# Altium schematic sheet Sheet1.SchDoc(Sheet1)
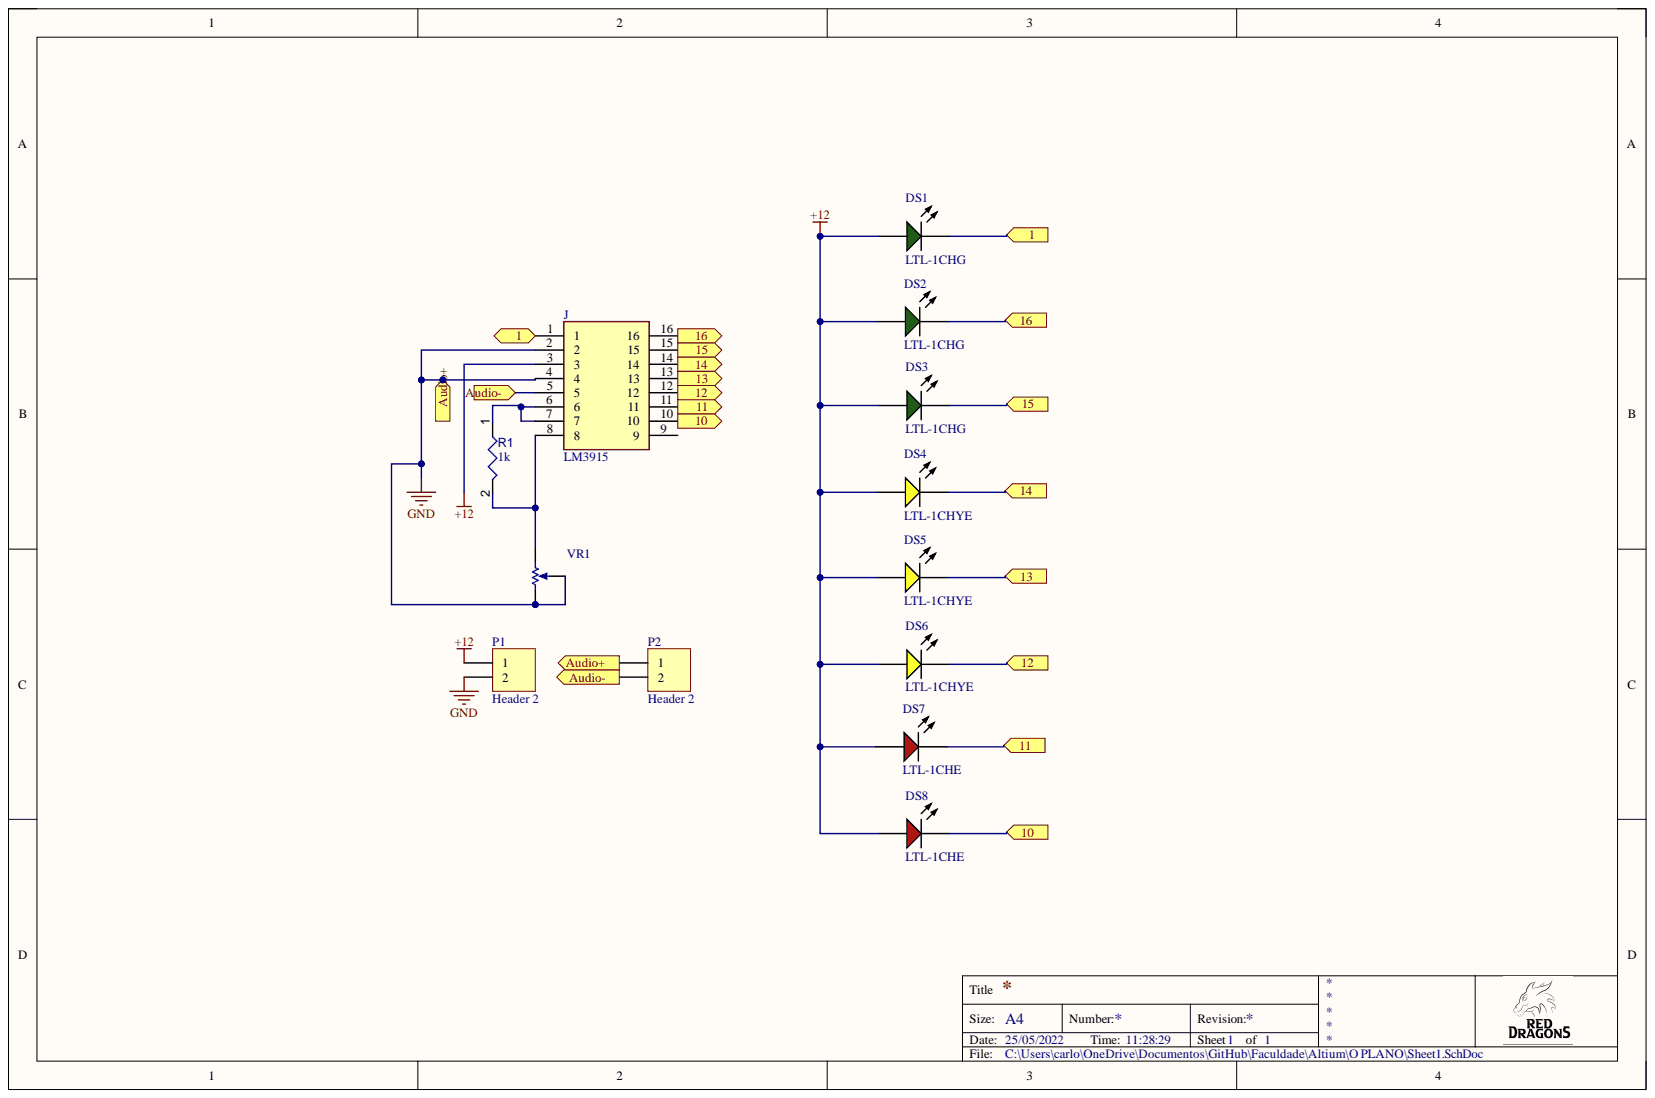
[diagram p0: Sheet1.SchDoc]

## Parts
DS1  LTL-1CHG  [LTST-LTL-1CHG-2]
DS2  LTL-1CHG  [LTST-LTL-1CHG-2]
DS3  LTL-1CHG  [LTST-LTL-1CHG-2]
DS4  LTL-1CHYE  [LTST-LTL-1CHYE]
DS5  LTL-1CHYE  [LTST-LTL-1CHYE]
DS6  LTL-1CHYE  [LTST-LTL-1CHYE]
DS7  LTL-1CHE  [INDPR60-254-520X380X650]
DS8  LTL-1CHE  [INDPR60-254-520X380X650]
J  LM3915  [TE_2-1571552-4]
P1  Header 2  [HDR1X2]
P2  Header 2  [HDR1X2]
R1  value 1k  [RESA60-630X240]  (hidden comment: MFR-25FBF52-1K)
VR1  3362P-1-103LF  [BOUR-3362P]  (value not drawn)

## Ports
1, 10, 11, 12, 13, 14, 15, 16, Audio+, Audio-

## Nets
+12: DS1.1 DS2.1 DS3.1 DS4.1 DS5.1 DS6.1 DS7.1 DS8.1 J.3 P1.1
GND: J.2 J.4 P1.2 P2.1 VR1.1 VR1.2
1: DS1.2 J.1 ; port 1
10: DS8.2 J.10 ; port 10
11: DS7.2 J.11 ; port 11
12: DS6.2 J.12 ; port 12
13: DS5.2 J.13 ; port 13
14: DS4.2 J.14 ; port 14
15: DS3.2 J.15 ; port 15
16: DS2.2 J.16 ; port 16
NetJ_5: J.5 P2.2
NetJ_6: J.6 J.7 R1.1
NetJ_8: J.8 R1.2 VR1.3

Not connected: J 9

## Library data of the parts
DS1-DS3 = CMP-007-00019-2: LED Uni-Color Green, 100 mW, 30 mA, -55 to 100 degC, 2-Pin THD, RoHS, Bulk; Manufacturer: Lite-On; Forward Current: 30mA; Forward Voltage: 2.6V; Lens Style: Dome; Luminous Intensity: 12.6mcd; Nominal Vf: 2.1V; Power: 100mW; Viewing Angle: 60degrees; Case/Package: Radial; Colour: Green; Component Kind: Standard; Datasheet URL: http://datasheet.octopart.com/LTL-1CHG-Lite-On-datasheet-143841.pdf; Datasheet Version: Mar-00; Illumination Colour: Green; Manufacturer URL: http://www.us.liteon.com/; Max Operating Temperature: 100°C; Min Operating Temperature: -55°C; Mounting Technology: TH; Package Description: 2-Pin Through Hole Device, Dia 3.8 mm; Package Quantity: 1000; Package Reference: LTL-1CHG; Packaging: Bulk; Pins: 2; RoHS Compliant: True; Library Name: Ufscar - Universidade Federal de São Carlos
DS4-DS6 = CMP-007-00020-2: LED Uni-Color Yellow, 60 mW, 20 mA, -55 to 100 degC, 2-Pin THD, RoHS, Bulk; Manufacturer: Lite-On; Forward Current: 20mA; Forward Voltage: 2.1V; Lens Style: Dome; Luminous Intensity: 40mcd; Viewing Angle: 45degrees; Case/Package: Radial; Colour: Yellow; Component Kind: Standard; Datasheet URL: https://datasheet.ciiva.com/4269/s-110-ltl-1chye-4269126.pdf; Datasheet Version: Rev. B, 07/2013; Illumination Colour: Yellow; Manufacturer URL: http://www.us.liteon.com/; Max Operating Temperature: 100°C; Min Operating Temperature: -55°C; Mounting Technology: TH; Nominal Vf: 2.1V; Package Description: 2-Pin Through Hole Device, Dia 3.8 mm, Pitch 2.54 mm; Package Quantity: 1000; Package Reference: LTL-1CHYE; Packaging: Bulk; Pins: 2; RoHS Compliant: True; Library Name: Ufscar - Universidade Federal de São Carlos
DS7 DS8 = CMP-007-00018-2: LED Uni-Color Red, 100 mW, 30 mA, -55 to 100 degC, 2-Pin THD, RoHS, Bulk; Manufacturer: Lite-On; Forward Current: 20mA; Forward Voltage: 2V; Lens Style: Dome; Luminous Intensity: 12.6mcd; Viewing Angle: 60degrees; Case/Package: Radial; Colour: Red; Component Kind: Standard; Datasheet URL: https://datasheet.ciiva.com/15117/getdatasheetpartid-187746-15117985.pdf; Datasheet Version: Dec-03; Illumination Colour: Red; Manufacturer URL: http://www.us.liteon.com/; Max Operating Temperature: 100°C; Min Operating Temperature: -55°C; Mounting Technology: TH; Nominal Vf: 2V; Package Description: 2-Pin Through Hole Device, Dia 3.8 mm, Pitch 2.54 mm; Package Quantity: 1000; Package Reference: LTL-1CHE; Packaging: Bulk; Pins: 2; RoHS Compliant: True; Library Name: Ufscar - Universidade Federal de São Carlos
J = 2-1571552-4: 16 (2 x 8) Pos DIP, 0.3 (7.62mm) Row Spacing Socket Gold Through Hole; Contact_Current_Rating_Max: 3 A; MF: TE Connectivity; Availability: Warning; Component Kind: Standard; EU_RoHS_Compliance: Compliant with Exemptions; Library Name: 2-1571552-4.IntLib; Mouser-Purchase-URL: https://snapeda.com/shop?store=Mouser&id=454027; MP: 2-1571552-4; Package: None; Price: None; Product_Type: Socket; SnapEDA_Link: https://www.snapeda.com/parts/2-1571552-4/TE%20Connectivity%20AMP%20Connectors/view-part/454027/?ref=snap
P1 P2 = Header 2: Header, 2-Pin; Component Kind: Standard; LatestRevisionDate: 17-Jul-2002; LatestRevisionNote: Re-released for DXP Platform.; Library Name: Miscellaneous Connectors.IntLib; Signal Integrity: Connector; Publisher: Altium Limited
R1 = CMP-009-00048-4: Axial Resistor, 1 KOhm, +/- 1%, 250 mW, -55 to 155 degC, 2-Pin THD, RoHS, Bulk; Manufacturer: Yageo; Power: 250mW; Voltage Rating: 250V; Case/Package: Axial; Component Kind: Standard; Composition: CarbonFilm; Datasheet URL: http://www.yageo.com/documents/recent/Yageo%20LR_MFR_2013.pdf; Datasheet Version: Apr-13; Manufacturer URL: http://www.yageo.com/; Max Operating Temperature: 155°C; Min Operating Temperature: -55°C; Mounting Technology: TH; Package Description: 2-Pin Axial Lead, Dia 2.4 mm, Body Length 6.3 mm; Package Quantity: 200; Package Reference: MFR-25; Packaging: Bulk; RoHS Compliant: True; Tolerance: 1%; Library Name: Ufscar - Universidade Federal de São Carlos
VR1 = CMP-009-00039-2: Square Trimpot(R) Trimming Potentiometer, 10 KOhm, 300 V, -55 to 125 degC, 3-Pin THD, RoHS, Tube; Manufacturer: Bourns; Power: 500mW; Resistance: 10k; Tolerance: 10%; Voltage Rating: 300V; Case/Package: 3326P; Component Kind: Standard; Composition: Potentiometer; Datasheet URL: http://www.bourns.com/docs/Product-Datasheets/3362.pdf; Datasheet Version: May-16; Lead Pitch: 2.54mm; Manufacturer URL: http://www.bourns.com/; Max Operating Temperature: 125°C; Min Operating Temperature: -55°C; Mounting Technology: TH; Package Description: 3-Pin Through Hole Device, Body 6.6 x 6.99 mm, Pitch 2.54 mm; Package Quantity: 250; Package Reference: 3326P; Packaging: Rail/Tube; Pins: 3; RoHS Compliant: True; Library Name: Ufscar - Universidade Federal de São Carlos
